# Altium schematic sheet 2225B_VumetreFrequenciel.SchDoc
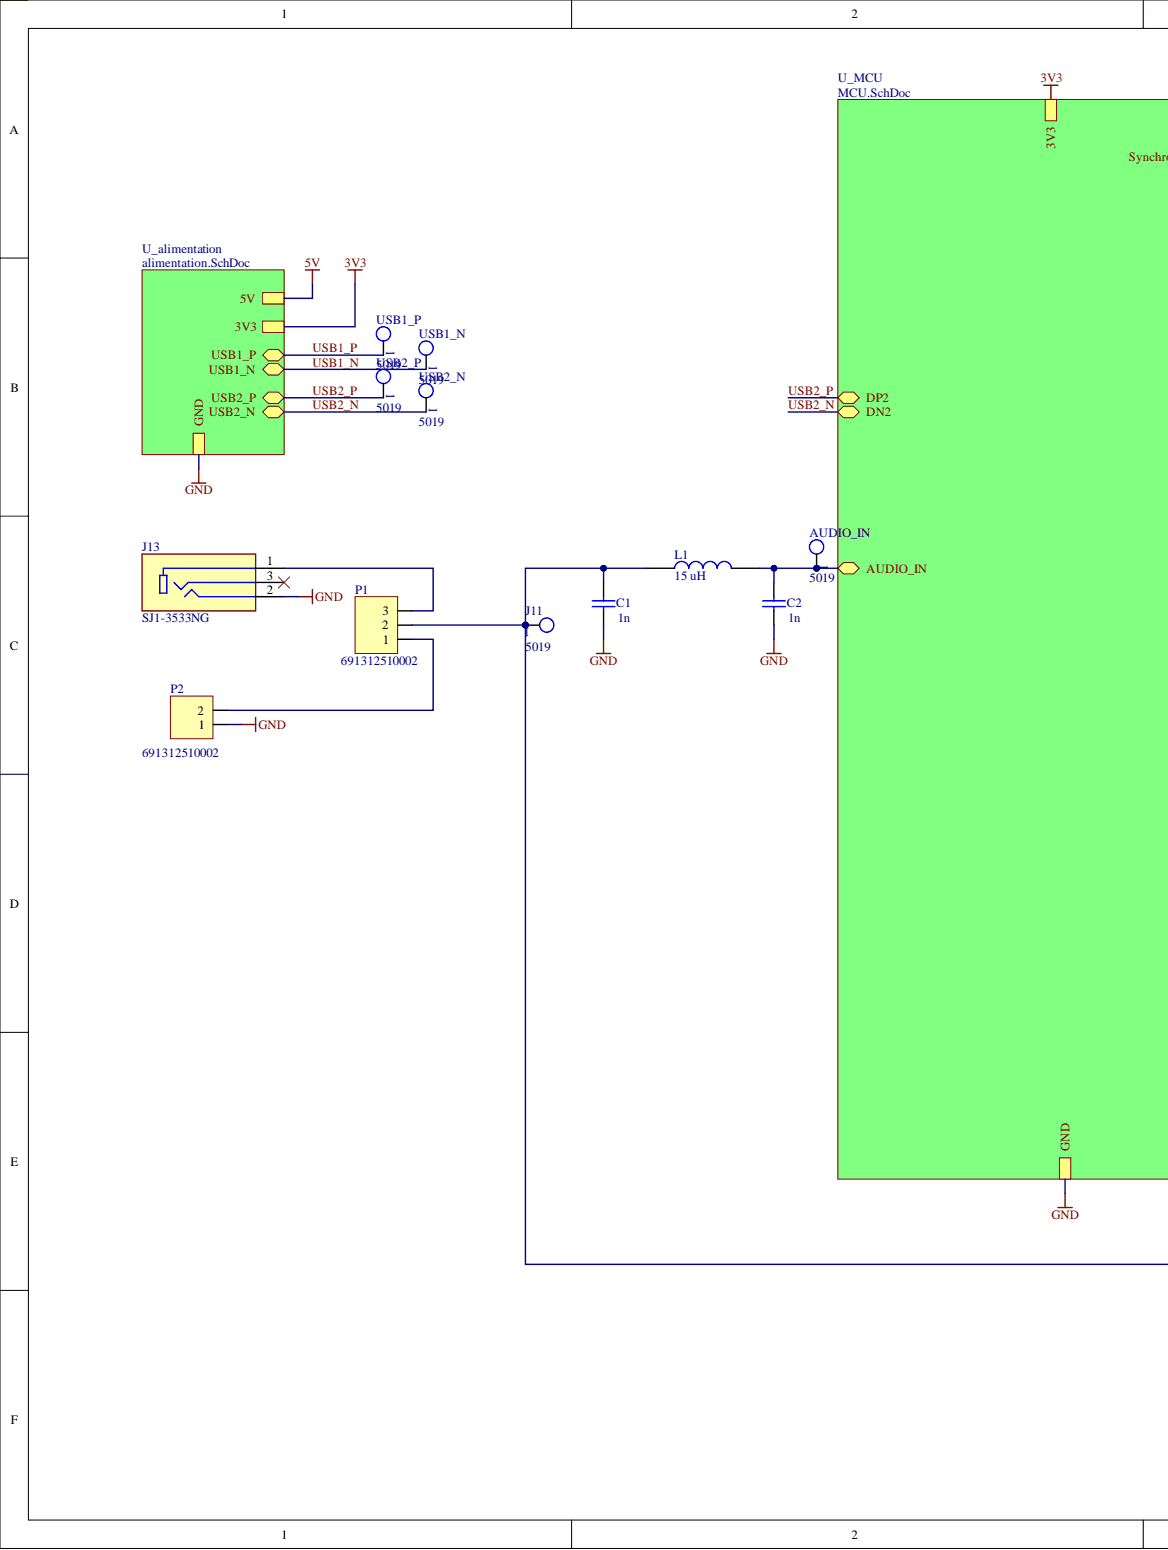
[diagram p0: 2225B_VumetreFrequenciel.SchDoc, left]
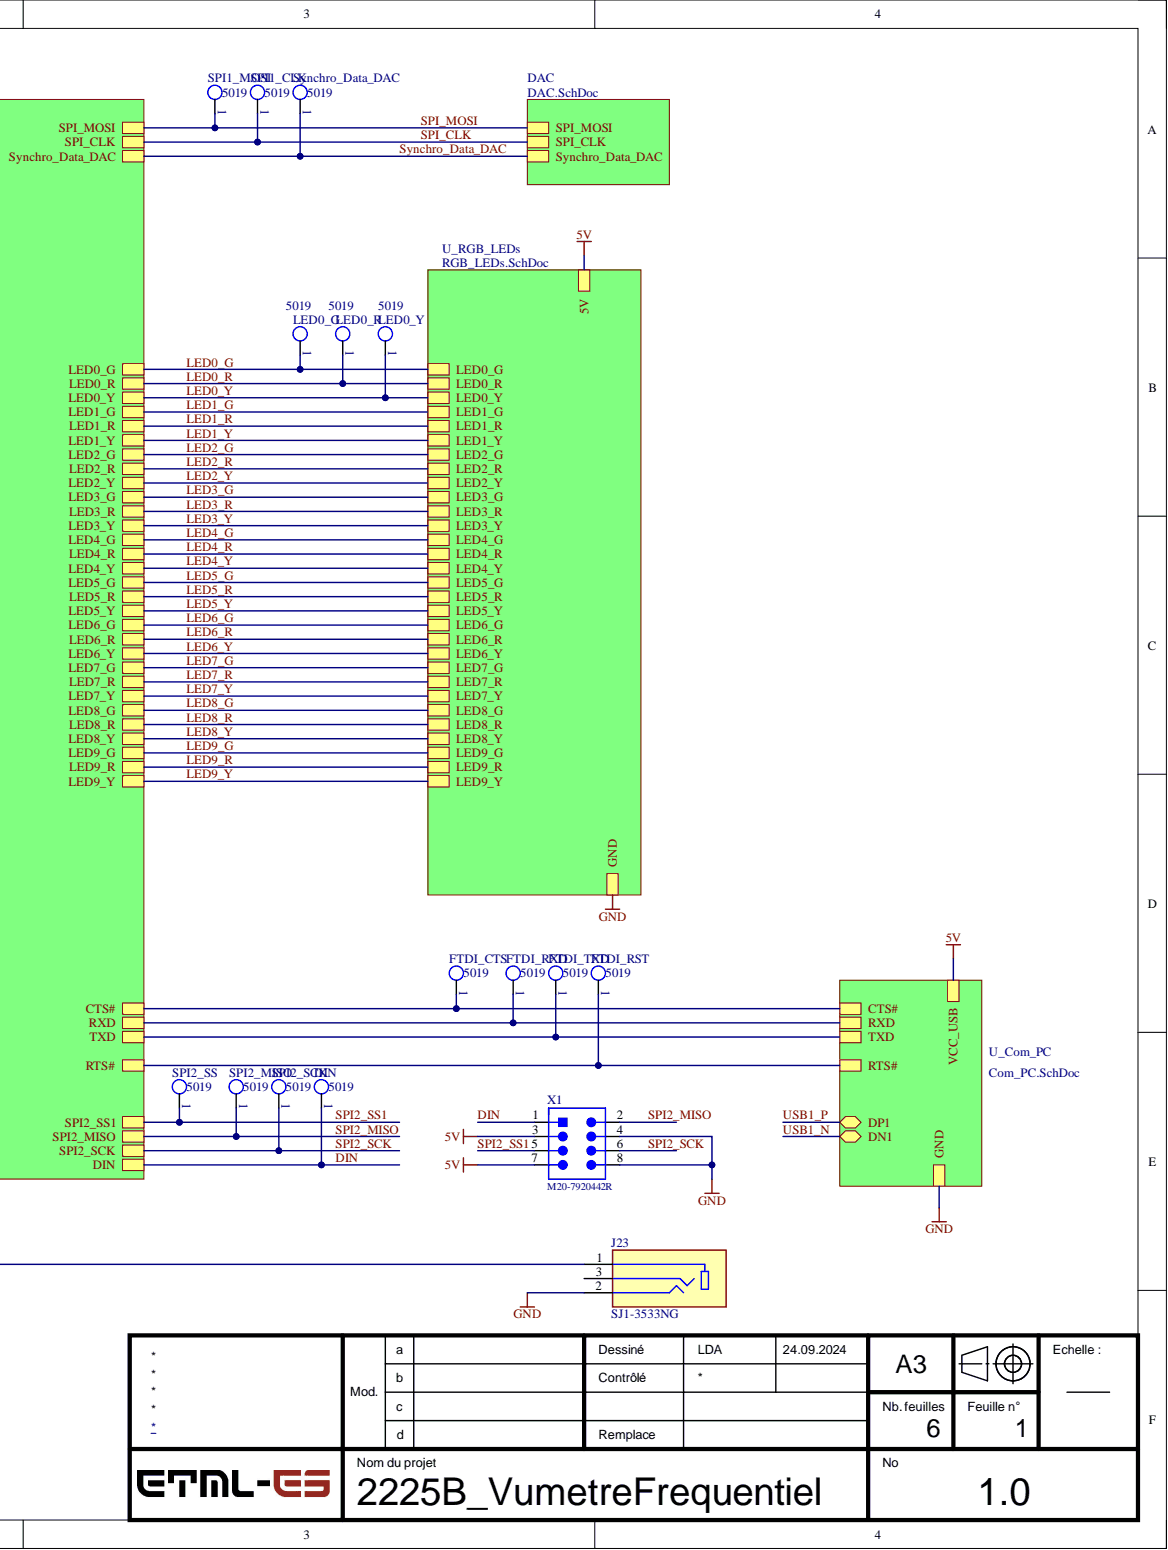
[diagram p1: 2225B_VumetreFrequenciel.SchDoc, right]

## Parts
AUDIO_IN  5019  [KEYSTONE_5019]
C1  1n  [C0805]
C2  1n  [C0805]
DIN  5019  [KEYSTONE_5019]
FTDI_CTS  5019  [KEYSTONE_5019]
FTDI_RST  5019  [KEYSTONE_5019]
FTDI_RXD  5019  [KEYSTONE_5019]
FTDI_TXD  5019  [KEYSTONE_5019]
J11  5019  [KEYSTONE_5019]
J13  SJ1-3533NG  [CUI_SJ1-3533NG]
J23  SJ1-3533NG  [CUI_SJ1-3533NG]
L1  15 uH  [WE-PD_1210]
LED0_G  5019  [KEYSTONE_5019]
LED0_R  5019  [KEYSTONE_5019]
LED0_Y  5019  [KEYSTONE_5019]
P1  691312510002  [61300311121]
P2  691312510002  [LINX_CONBNC002]
SPI1_CLK  5019  [KEYSTONE_5019]
SPI1_MOSI  5019  [KEYSTONE_5019]
SPI2_MISO  5019  [KEYSTONE_5019]
SPI2_SCK  5019  [KEYSTONE_5019]
SPI2_SS  5019  [KEYSTONE_5019]
Synchro_Data_DAC  5019  [KEYSTONE_5019]
USB1_N  5019  [KEYSTONE_5019]
USB1_P  5019  [KEYSTONE_5019]
USB2_N  5019  [KEYSTONE_5019]
USB2_P  5019  [KEYSTONE_5019]
X1  M20-7920442R  [610108249121]

## Nets
5V: X1.3 X1.7
GND: C1.2 C2.2 J13.2 J23.2 P2.1 X1.4 X1.8
DIN: DIN.1 X1.1
LED0_G: LED0_G.1
LED0_R: LED0_R.1
LED0_Y: LED0_Y.1
SPI2_MISO: SPI2_MISO.1 X1.2
SPI2_SCK: SPI2_SCK.1 X1.6
SPI2_SS1: SPI2_SS.1 X1.5
SPI_CLK: SPI1_CLK.1
SPI_MOSI: SPI1_MOSI.1
Synchro_Data_DAC: Synchro_Data_DAC.1
USB1_N: USB1_N.1
USB1_P: USB1_P.1
USB2_N: USB2_N.1
USB2_P: USB2_P.1
NetAUDIO_IN_1: AUDIO_IN.1 C2.1 L1.2
NetC1_1: C1.1 J11.1 J23.1 L1.1 P1.2
NetFTDI_CTS_1: FTDI_CTS.1
NetFTDI_RST_1: FTDI_RST.1
NetFTDI_RXD_1: FTDI_RXD.1
NetFTDI_TXD_1: FTDI_TXD.1
NetJ13_1: J13.1 P1.3
NetJ13_3: J13.3
NetJ23_3: J23.3
NetP1_1: P1.1 P2.2
3V3:
LED1_G:
LED1_R:
LED1_Y:
LED2_G:
LED2_R:
LED2_Y:
LED3_G:
LED3_R:
LED3_Y:
LED4_G:
LED4_R:
LED4_Y:
LED5_G:
LED5_R:
LED5_Y:
LED6_G:
LED6_R:
LED6_Y:
LED7_G:
LED7_R:
LED7_Y:
LED8_G:
LED8_R:
LED8_Y:
LED9_G:
LED9_R:
LED9_Y:

## Library data of the parts
AUDIO_IN DIN FTDI_CTS FTDI_RST FTDI_RXD FTDI_TXD J11 SPI1_CLK SPI1_MOSI SPI2_MISO SPI2_SCK SPI2_SS Synchro_Data_DAC USB1_N USB1_P USB2_N USB2_P = CMP-1672-00008-2: Manufacturer: Keystone Electronics; NexarPartId: 22052288; Number of Contacts: 1; Component Kind: Standard; Contact Material: Bronze, Silver; Contact Plating: Silver; Height: 2.286mm; Lead Free: Lead Free; Manufacturer Part Number: 5019; Material: Bronze; Mount: Surface Mount; Number of Pins: 1; Packaging: Tape and Reel; REACH SVHC: No SVHC; RoHS: Compliant; Termination: SMD/SMT; Library Name: 2225B_VumetreFrequenciel.SchLib
C1 C2 = Cap: Capacitor; Component Kind: Standard; LatestRevisionDate: 17-Jul-2002; LatestRevisionNote: Re-released for DXP Platform.; Library Name: Miscellaneous Devices.IntLib; PackageDescription: Radial Cap, Thru-Hole; 2 Leads; 0.3 in Pin Spacing; PackageReference: RAD-0.3; Published: 8-Jun-2000; Signal Integrity: Cap; Simulation: CAP; Value: 1n; Publisher: Altium Limited
J13 J23 = SJ1-3533NG: 3.5 mm Right-Angle Stereo Jack, 3 Pin PCB Mount, Isolated Ground; MF: {'manufacturer': u'CUI'}; Availability: Unavailable; Component Kind: Standard; MP: SJ1-3533NG; Package: None; Price: None; Library Name: 2227_BoiteBruitage.SchLib
L1 = CDRH125NP-220MC: Fixed Inductors 22uH 2.80A 0.028ohms; Manufacturer_Name: Sumida; Geometry.Height: 6mm; Component Kind: Standard; Datasheet Link: http://products.sumida.com/products/pdf/CDRH125,CDRH125LD.pdf; Manufacturer_Part_Number: CDRH125NP-220MC; Library Name: TP2_Convertisseur_Courant.SchLib
LED0_G LED0_R LED0_Y = CMP-1672-00008-2: Manufacturer: Keystone Electronics; NexarPartId: 22052288; Material: Bronze; Number of Contacts: 1; Component Kind: Standard; Contact Material: Bronze, Silver; Contact Plating: Silver; Height: 2.286mm; Lead Free: Lead Free; Manufacturer Part Number: 5019; Mount: Surface Mount; Number of Pins: 1; Packaging: Tape and Reel; REACH SVHC: No SVHC; RoHS: Compliant; Termination: SMD/SMT; Library Name: 2225B_VumetreFrequenciel.SchLib
P1 = MHDR1X3: Header, 3-Pin; Component Kind: Standard; LatestRevisionDate: 17-Jul-2002; LatestRevisionNote: Re-released for DXP Platform.; Signal Integrity: Connector; Library Name: 2225B_VumetreFrequenciel.SchLib; Publisher: Altium Limited
P2 = MHDR1X2: Header, 2-Pin; Component Kind: Standard; LatestRevisionDate: 17-Jul-2002; LatestRevisionNote: Re-released for DXP Platform.; Signal Integrity: Connector; Library Name: 2225B_VumetreFrequenciel.SchLib; Publisher: Altium Limited
X1 = 610108249121: WR-PHD Pin Header, THT, pitch 2.54mm, Dual Row, Angled, 8p; Manufacturer: Wurth Elektronik; Manufacturer Part Number: 61300821021; Contact Resistance: 20mOhm; Pin Number: 8; Rated Current: 3A; Withstanding Voltage: 500VAC; Working Voltage: 250VAC; Component Kind: Standard; ComponentLink1Description: Manufacturer; ComponentLink1URL: http://www.we-online.de; ComponentLink2Description: Datasheet; ComponentLink2URL: https://www.we-online.com/catalog/datasheet/61300821021.pdf; Gender: Pin Header; Mounting Technology: THT; Operating Temperature: -40 to +105degC; Orientation: Angled; Packaging: Bag; Pitch: 2.54mm; Row Number: Dual; Library Name: 2126_AffichageMatriciel.SchLib
